# Altium schematic sheet SmartSwitch P1.SchDoc
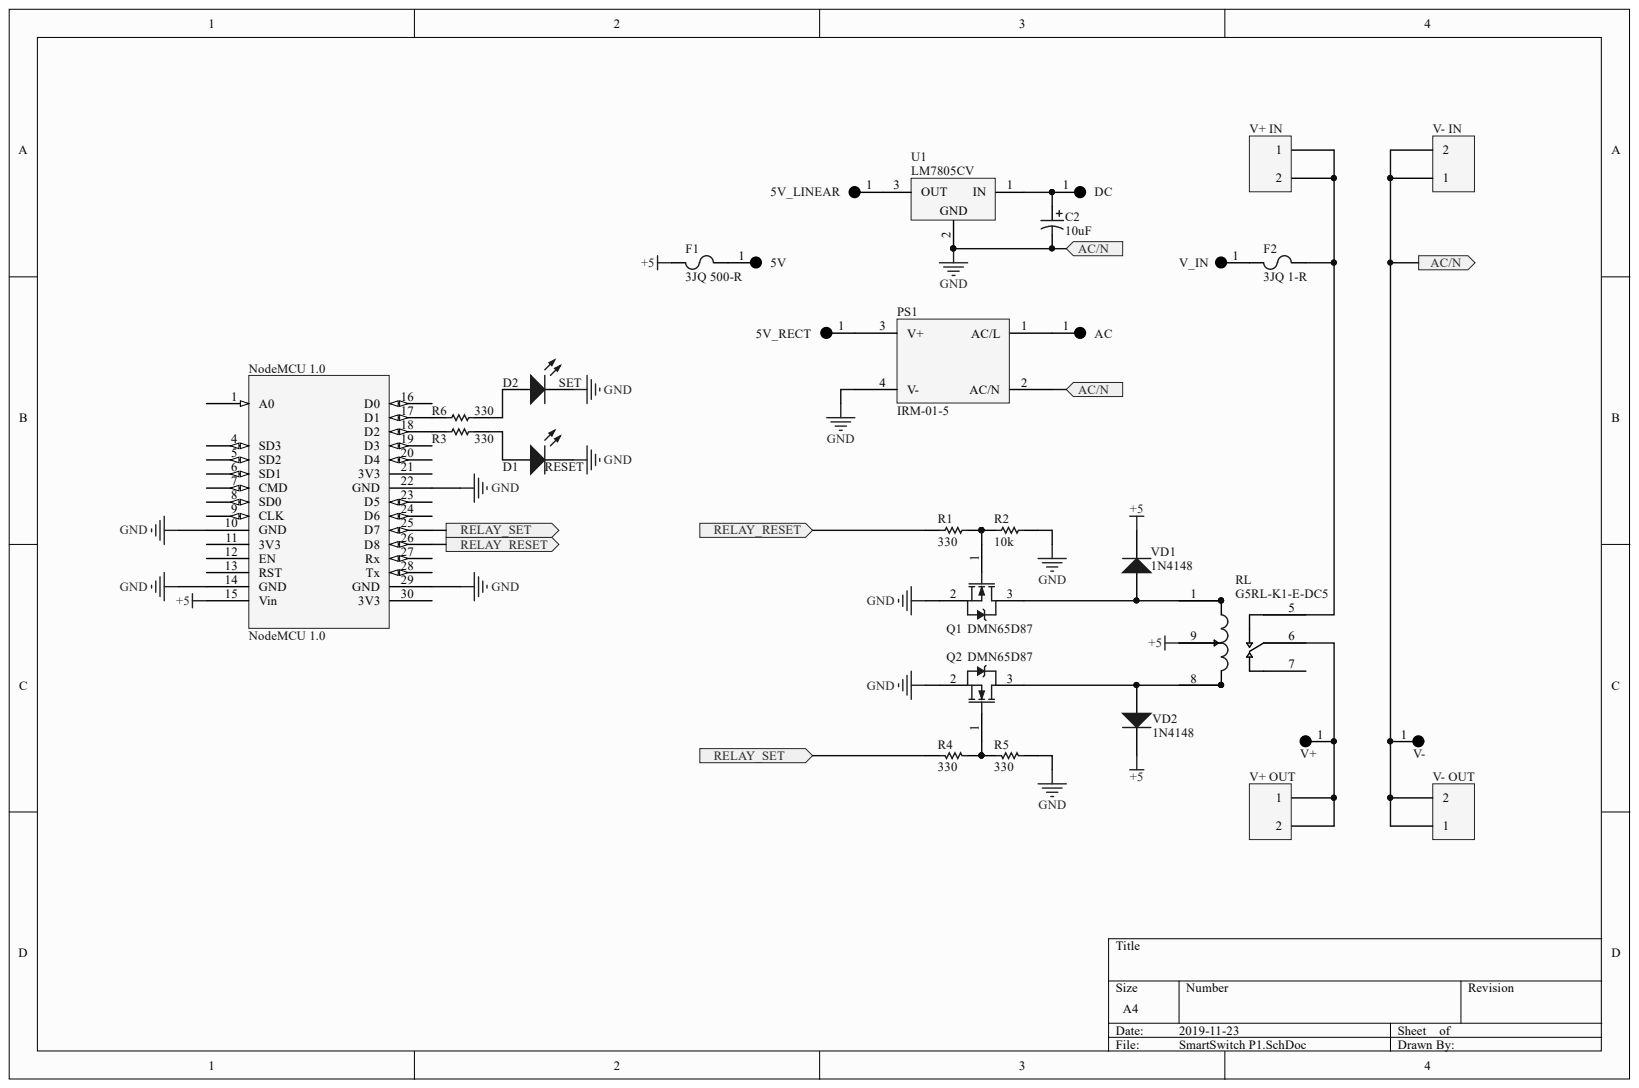
[diagram p0: SmartSwitch P1.SchDoc]

## Parts
5V  Keystone 5011  [PCB-nje03k9v44gt2snsz3w9-1]  (value not drawn)
5V_LINEAR  Keystone 5011  [PCB-nje03k9v44gt2snsz3w9-1]  (value not drawn)
5V_RECT  Keystone 5011  [PCB-nje03k9v44gt2snsz3w9-1]  (value not drawn)
AC  Keystone 5011  [PCB-nje03k9v44gt2snsz3w9-1]  (value not drawn)
C2  10uF  [PCB-8a2jo8mm5ouvy6qho5ny-1]
D1  RESET  [PCB-qe300kdc9tuz1owjehq9-1]
D2  SET  [PCB-qe300kdc9tuz1owjehq9-1]
DC  Keystone 5011  [PCB-nje03k9v44gt2snsz3w9-1]  (value not drawn)
F1  3JQ 500-R  [PCB-vxippmkvw2szlmf2btqj-1]
F2  3JQ 1-R  [PCB-ytctgmw176utm1gehaug-1]
NodeMCU_1.0  NodeMCU 1.0  [PCB-4f2jj0tqcwafqtaaud9f-1]
PS1  IRM-01-5  [PCB-9z1y1qd629hvy7vu8n4b-1]
Q1  DMN65D87  [PCB-nw73lpgy3xhhfuwv1f85-1]
Q2  DMN65D87  [PCB-nw73lpgy3xhhfuwv1f85-1]
R1  330  [RESC1608X06M]
R2  10k  [RESC1608X06M]
R3  330  [RESC1608X06M]
R4  330  [RESC1608X06M]
R5  330  [RESC1608X06M]
R6  330  [RESC1608X06M]
RL  G5RL-K1-E-DC5  [PCB-yec2uco0ixtl2yrw8m1g-1]
U1  LM7805CV  [PCB-m217x3dwscnnq8k6ksmn-1]
V+  Keystone 5011  [PCB-nje03k9v44gt2snsz3w9-1]  (value not drawn)
V+_IN  TE Connectivity 796949-2  [PCB-genlv6ka4twigaugn851-1]  (value not drawn)
V+_OUT  TE Connectivity 796949-2  [PCB-genlv6ka4twigaugn851-1]  (value not drawn)
V-  Keystone 5011  [PCB-nje03k9v44gt2snsz3w9-1]  (value not drawn)
V-_IN  TE Connectivity 796949-2  [PCB-genlv6ka4twigaugn851-1]  (value not drawn)
V-_OUT  TE Connectivity 796949-2  [PCB-genlv6ka4twigaugn851-1]  (value not drawn)
V_IN  Keystone 5011  [PCB-nje03k9v44gt2snsz3w9-1]  (value not drawn)
VD1  1N4148  [PCB-2bm24o7p3s75zc4txknh-1]
VD2  1N4148  [PCB-2bm24o7p3s75zc4txknh-1]

## Ports
AC/N, RELAY_RESET, RELAY_SET

## Nets
+5: F1.1 NodeMCU_1.0.15(Vin) RL.9 VD1.2 VD2.2
GND: C2.2 D1.2 D2.2 NodeMCU_1.0.10(GND) NodeMCU_1.0.14(GND) NodeMCU_1.0.22(GND) NodeMCU_1.0.29(GND) PS1.2(AC/N) PS1.4(V-) Q1.2 Q2.2 R2.2 R5.2 U1.2 V-.1 V-_IN.1 V-_IN.2 V-_OUT.1 V-_OUT.2 ; port AC/N
RELAY_RESET: NodeMCU_1.0.26(D8) R1.1 ; port RELAY_RESET
RELAY_SET: NodeMCU_1.0.25(D7) R4.1 ; port RELAY_SET
Net5V_1: 5V.1 F1.2
Net5V_LINEAR_1: 5V_LINEAR.1 U1.3
Net5V_RECT_1: 5V_RECT.1 PS1.3(V+)
NetAC_1: AC.1 PS1.1(AC/L)
NetC2_1: C2.1 DC.1 U1.1
NetD1_1: D1.1 R3.1
NetD2_1: D2.1 R6.1
NetF2_1: F2.1 RL.5 V+_IN.1 V+_IN.2
NetF2_2: F2.2 V_IN.1
NetNodeMCU 1.0_17: NodeMCU_1.0.17(D1) R6.2
NetNodeMCU 1.0_18: NodeMCU_1.0.18(D2) R3.2
NetQ1_1: Q1.1 R1.2 R2.1
NetQ1_3: Q1.3 RL.1 VD1.1
NetQ2_1: Q2.1 R4.2 R5.1
NetQ2_3: Q2.3 RL.2 RL.8 VD2.1
NetRL_6: RL.6 V+.1 V+_OUT.1 V+_OUT.2

Not connected: NodeMCU_1.0 1(A0) 4(SD3) 5(SD2) 6(SD1) 7(CMD) 8(SD0) 9(CLK) 11(3V3) 12(EN) 13(RST) 16(D0) 19(D3) 20(D4) 21(3V3) 23(D5) 24(D6) 27(Rx) 28(Tx) 30(3V3); RL 7

## Library data of the parts
5V 5V_LINEAR 5V_RECT AC DC V+ V- V_IN = 5011: Test Point; Black; Thru-Hole; Snap-Fit; SilverPlate; 0.063in. Dia.; 0.445In.; Component Kind: Net Tie; Library Name: Octopart library
C2 = ESK105M050AC3AA: Cap, Alu Elec, 1uf, 50v, Rad; Manufacturer: Kemet; Capacitance: 1 µF; Capacitance Tolerance: ±20%; Component Kind: Standard; Composition: Aluminum; Library Name: Octopart library; Manufacturer Part Number: ESK105M050AC3AA; OctopartID: 88fc40a516b0573b; Octopart URL: http://octopart.com/esk105m050ac3aa-kemet-22264618; REACH SVHC Compliance: No SVHC; RoHS: Compliant; Size-Diameter: 5 mm; Size-Height: 11 mm; Voltage Rating (DC): 50 V
D1 D2 = HLMP-D150: LED Uni-Color Red 645nm 2-Pin T-1 3/4; Current Rating: 30.0 mA; Lead-Free Status: Lead Free; Lead Length: 25.0 mm; Lens Color: Clear; Lens Type: Diffused; Luminous Intensity: 3.00 mcd; Viewing Angle: 65 °; Wavelength: 637 nm, 626 nm; Component Kind: Standard; Library Name: Octopart library; Manufacturer Part Number: HLMP-D150; Mounting Style: Through Hole, Vertical; OctopartID: 379cfaab4cbae85b; Packaging: Bulk; Peak Wavelength: 645 nm; REACH SVHC Compliance: No SVHC; RoHS: Compliant; Size-Diameter: 5.00 mm; Size-Height: 4.70 mm
F1 = CMP-2a4ce34e958df58b-1: Fuse Subminiature Fast Acting 0.5A 350V Axial 5 X 15mm Glass CE/CSA/UL/cULus; Current Rating: 500 mA; Lead-Free Status: Lead Free; Component Kind: Standard; Library Name: FavoritesLibrary; Manufacturer Part Number: 3JQ 500-R; OctopartID: 2a4ce34e958df58b; Octopart URL: https://octopart.com/3jq+500-r-bel+fuse-563879; Operating Temperature: 125 °C (max); Packaging: Bulk; RoHS: Compliant; Size-Diameter: 5.50 mm; Size-Length: 15.0 mm; Voltage Rating (DC): 350 V
F2 = CMP-72fabbf8cd1bad3d-1: Fuse Subminiature Fast Acting 1A 350V Axial 5 X 15mm Glass CE/CSA/PSE/UL/cULus; Current Rating: 1.00 A; Lead-Free Status: Lead Free; Component Kind: Standard; Library Name: FavoritesLibrary; Manufacturer Part Number: 3JQ 1-R; OctopartID: 72fabbf8cd1bad3d; Octopart URL: https://octopart.com/3jq+1-r-bel+fuse-563847; Operating Temperature: 125 °C (max); Packaging: Bulk; RoHS: Compliant; Size-Diameter: 5.50 mm; Size-Length: 15.0 mm; Voltage Rating (DC): 350 V
NodeMCU_1.0 = CMP-46578cb04ca89990-2: Nodemcu V2 Lua Based ESP8266 Dev; Manufacturer Part Number: 113990105; Component Kind: Standard; Library Name: FavoritesLibrary; OctopartID: 46578cb04ca89990; Octopart URL: https://octopart.com/113990105-seeed+studio-65875865
PS1 = CMP-ypu2c5gq6vpv0iibqbva-8: AC/DC CONVERTER 5V 1W; Component Kind: Standard; CustomComponentID: ypu2c5gq6vpv0iibqbva; Library Name: FavoritesLibrary
Q1 Q2 = DMN65D8L-7: Trans MOSFET N-CH 60V 0.31A Automotive 3-Pin SOT-23 T/R; Lead-Free Status: Lead Free; Component Kind: Standard; Library Name: Octopart library; Package / Case: TO-236-3, SC-59, SOT-23-3
R1 R3-R6 = CMP-1846135-10: Precision resistor, SMD [Panasonic Automotive & Industrial Systems] ERJ3EKF3300V Precision resistor, SMD; Component Kind: Standard; Library Name: CommunityVault
R2 = CMP-1846091-22: Resistor Thick Film 0603 10k Ohm 1% 1/10 Watt ±100ppm °C Surface Mount Punched Carrier Tape and Reel; Manufacturer Part Number: ERJ-3EKF1002V; Case/Package (SI): 1608; Power Rating: 100 mW; Resistance: 10.0 kΩ; Voltage Rating (DC): 75.0 V; Case/Package: 0603; Component Kind: Standard; Library Name: CommunityVault; Lifecycle Status: Not Listed by Manufacturer; Mounting Style: Surface Mount; Number of Pins: 2; Octopart URL: http://octopart.com/erj-3ekf1002v-panasonic-55403647; Packaging: Cut Tape (CT), Tape & Reel (TR); REACH SVHC Compliance: No SVHC; Resistance Tolerance: ±1%; RoHS: Compliant; Size-Height: 450 µm; Size-Length: 1.60 mm
RL = CMP-3aa7f14b0c48e560-4: General Purpose Relay, G5rl-K Series, Power, Latching Dual Coil, Spdt, 5 Vdc, 16 A; Component Kind: Standard; Contact Material: Silver; Contacts Type: SPDT; Library Name: FavoritesLibrary; Manufacturer Part Number: G5RL-K1-E-DC5; OctopartID: ac64665b124673a3; Octopart URL: https://octopart.com/g5rl-k1-e-dc5-omron-53844804; REACH SVHC Compliance: No SVHC; RoHS: Compliant
U1 = LM7805CT/NOPB: Linear voltage regulator [ST micro] LM7805CV Linear voltage regulator 2A THT; Component Kind: Standard; Library Name: Octopart library
V+_IN V+_OUT V-_IN V-_OUT = CMP-cbef00bfe96a1428-6: Connector Terminal Blocks 2 Position 5.08mm Solder Straight Thru-Hole 17.5A/Contact; Component Kind: Standard; Library Name: CommunityVault
VD1 VD2 = 1N4148W: Diode: switching; SMD; 100V; 0.15A; 4ns; 400mW; Package: reel, tape; Corpus: sod-123; Component Kind: Standard; Library Name: Octopart library; Manufacturer Part Number: 1N4148W; Mounting Style: Surface Mount; OctopartID: 93d6585914c84c11
